# Altium schematic sheet Zotino_BNC_Adapter.SchDoc
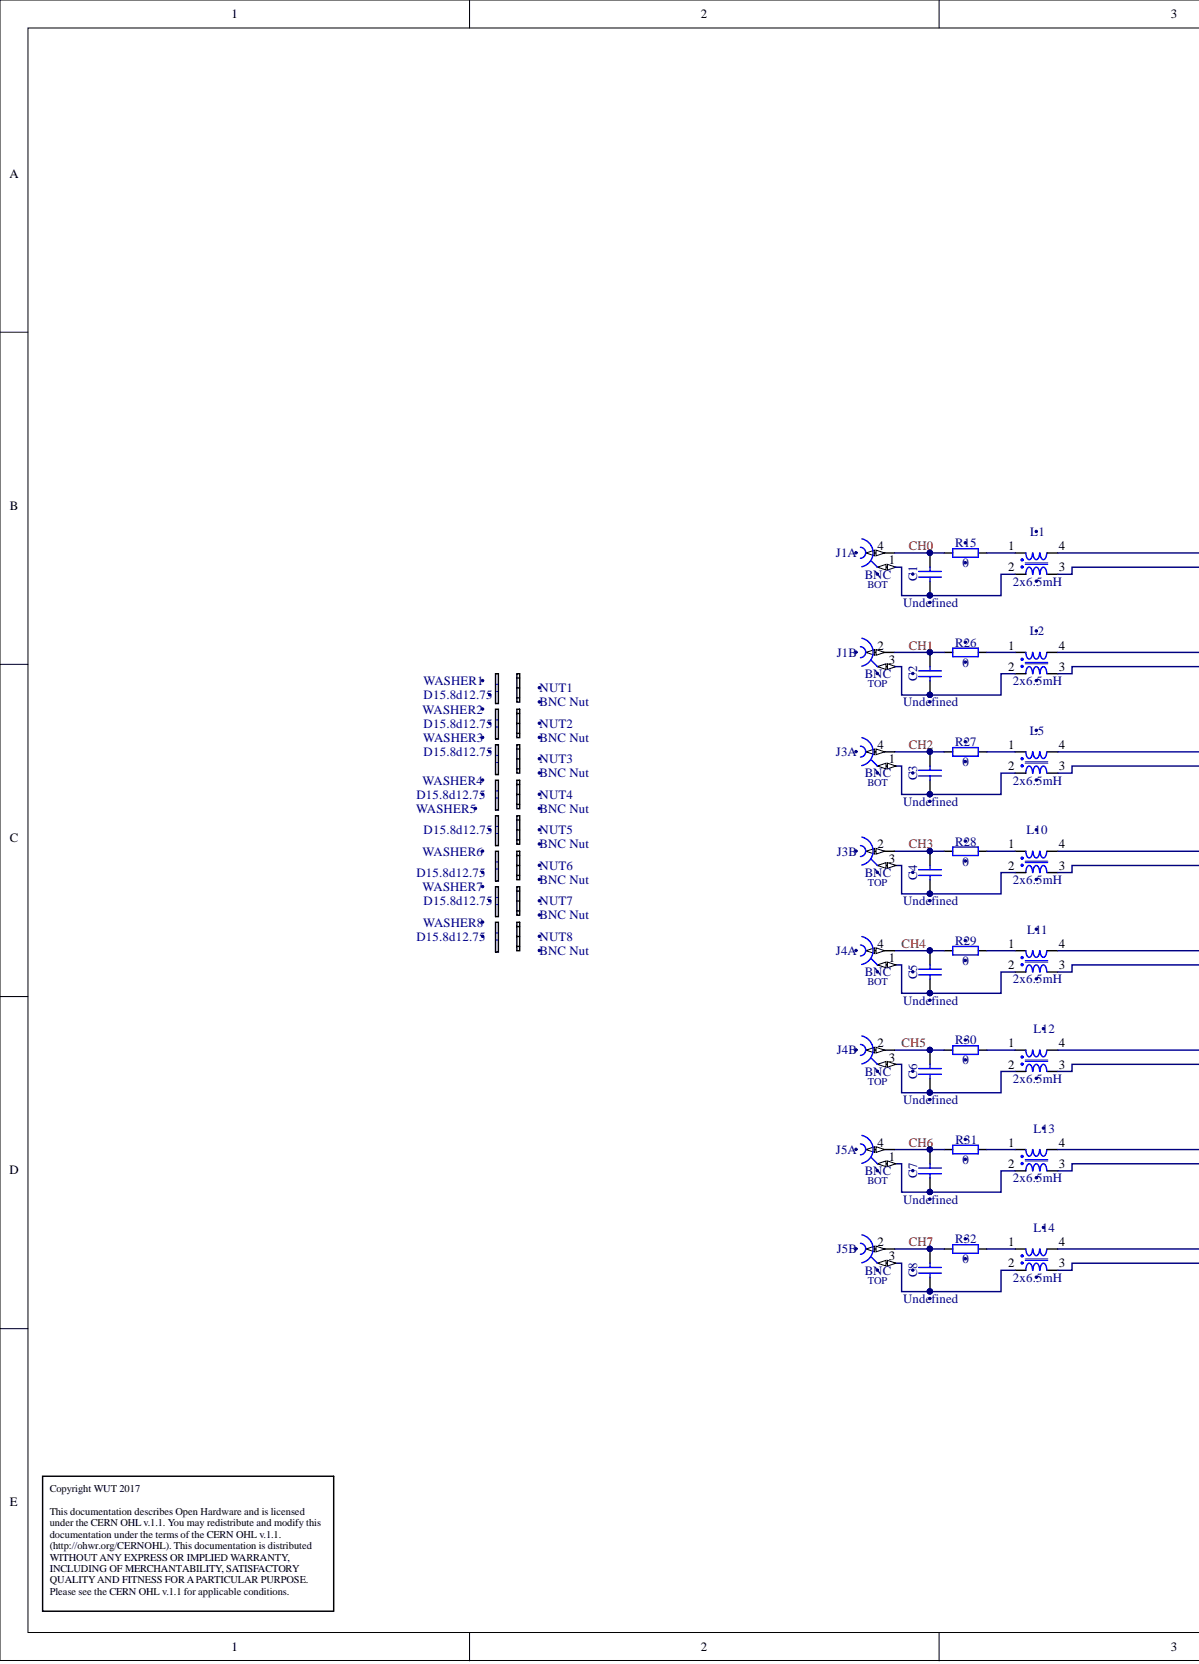
[diagram p0: Zotino_BNC_Adapter.SchDoc, left]
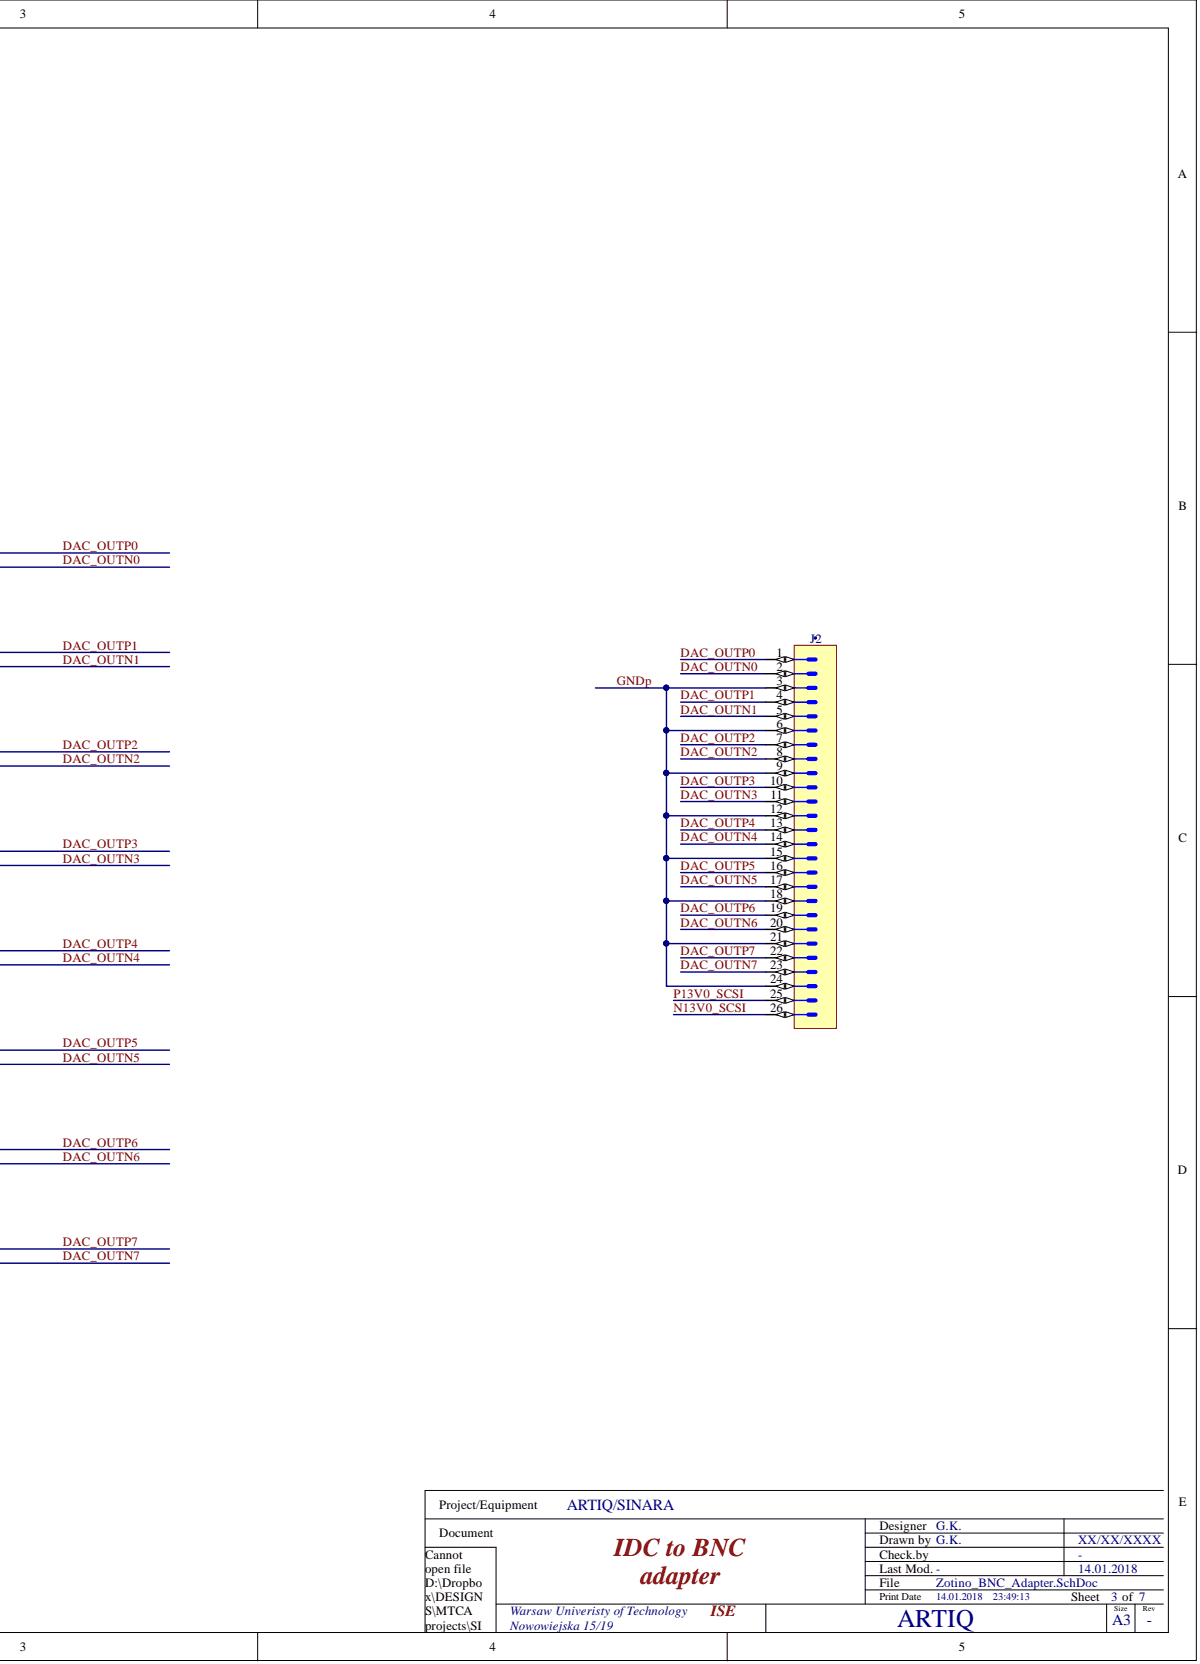
[diagram p1: Zotino_BNC_Adapter.SchDoc, right]

## Parts
C1  Undefined  [CAPC2012X135N]
C2  Undefined  [CAPC2012X135N]
C3  Undefined  [CAPC2012X135N]
C4  Undefined  [CAPC2012X135N]
C5  Undefined  [CAPC2012X135N]
C6  Undefined  [CAPC2012X135N]
C7  Undefined  [CAPC2012X135N]
C8  Undefined  [CAPC2012X135N]
J1  BNC  [RENHOTEC_RHT-610-0049]  (parts A,B)
J2  Header 26  [3M_N2526-6003RB]  (value not drawn)
J3  BNC  [RENHOTEC_RHT-610-0049]  (parts A,B)
J4  BNC  [RENHOTEC_RHT-610-0049]  (parts A,B)
J5  BNC  [RENHOTEC_RHT-610-0049]  (parts A,B)
L1  2x6.5mH  [IND_WURTH_WE-SL2]
L2  2x6.5mH  [IND_WURTH_WE-SL2]
L5  2x6.5mH  [IND_WURTH_WE-SL2]
L10  2x6.5mH  [IND_WURTH_WE-SL2]
L11  2x6.5mH  [IND_WURTH_WE-SL2]
L12  2x6.5mH  [IND_WURTH_WE-SL2]
L13  2x6.5mH  [IND_WURTH_WE-SL2]
L14  2x6.5mH  [IND_WURTH_WE-SL2]
NUT1  BNC Nut  [TE_1-329631-2]
NUT2  BNC Nut  [TE_1-329631-2]
NUT3  BNC Nut  [TE_1-329631-2]
NUT4  BNC Nut  [TE_1-329631-2]
NUT5  BNC Nut  [TE_1-329631-2]
NUT6  BNC Nut  [TE_1-329631-2]
NUT7  BNC Nut  [TE_1-329631-2]
NUT8  BNC Nut  [TE_1-329631-2]
R15  0  [RESC1608X55N]
R26  0  [RESC1608X55N]
R27  0  [RESC1608X55N]
R28  0  [RESC1608X55N]
R29  0  [RESC1608X55N]
R30  0  [RESC1608X55N]
R31  0  [RESC1608X55N]
R32  0  [RESC1608X55N]
WASHER1  D15.8d12.75  [TE_1-1634817-0]
WASHER2  D15.8d12.75  [TE_1-1634817-0]
WASHER3  D15.8d12.75  [TE_1-1634817-0]
WASHER4  D15.8d12.75  [TE_1-1634817-0]
WASHER5  D15.8d12.75  [TE_1-1634817-0]
WASHER6  D15.8d12.75  [TE_1-1634817-0]
WASHER7  D15.8d12.75  [TE_1-1634817-0]
WASHER8  D15.8d12.75  [TE_1-1634817-0]

## Nets
CH0: C1.2 J1.4 R15.1
CH1: C2.2 J1.2 R26.1
CH2: C3.2 J3.4 R27.1
CH3: C4.2 J3.2 R28.1
CH4: C5.2 J4.4 R29.1
CH5: C6.2 J4.2 R30.1
CH6: C7.2 J5.4 R31.1
CH7: C8.2 J5.2 R32.1
DAC_OUTN0: J2.2 L1.3
DAC_OUTN1: J2.5 L2.3
DAC_OUTN2: J2.8 L5.3
DAC_OUTN3: J2.11 L10.3
DAC_OUTN4: J2.14 L11.3
DAC_OUTN5: J2.17 L12.3
DAC_OUTN6: J2.20 L13.3
DAC_OUTN7: J2.23 L14.3
DAC_OUTP0: J2.1 L1.4
DAC_OUTP1: J2.4 L2.4
DAC_OUTP2: J2.7 L5.4
DAC_OUTP3: J2.10 L10.4
DAC_OUTP4: J2.13 L11.4
DAC_OUTP5: J2.16 L12.4
DAC_OUTP6: J2.19 L13.4
DAC_OUTP7: J2.22 L14.4
GNDp: J2.3 J2.6 J2.9 J2.12 J2.15 J2.18 J2.21 J2.24
N13V0_SCSI: J2.26
P13V0_SCSI: J2.25
NetC1_1: C1.1 J1.1 L1.2
NetC2_1: C2.1 J1.3 L2.2
NetC3_1: C3.1 J3.1 L5.2
NetC4_1: C4.1 J3.3 L10.2
NetC5_1: C5.1 J4.1 L11.2
NetC6_1: C6.1 J4.3 L12.2
NetC7_1: C7.1 J5.1 L13.2
NetC8_1: C8.1 J5.3 L14.2
NetL1_1: L1.1 R15.2
NetL2_1: L2.1 R26.2
NetL5_1: L5.1 R27.2
NetL10_1: L10.1 R28.2
NetL11_1: L11.1 R29.2
NetL12_1: L12.1 R30.2
NetL13_1: L13.1 R31.2
NetL14_1: L14.1 R32.2

## Library data of the parts
C1-C8 = CC0805_NO-VALUE: Non-polarised capacitor; Part Description: Reserved Footprint For 0805(2012) Chip Ceramic Capacitor; Database Table Name: Capacitors SMD; Mounted: No; Case: 0805; Component Kind: Standard; Component Type: Standard; CreateDate: 10.12.2008; HelpURL: Undefined; LatestRevisionDate: 11.09.2013; Library Name: Capacitors.DbLib; Manufacturer: Undefined; Manufacturer1 ComponentHeight: 1.35mm; Manufacturer Part Number: CC0805_NO-VALUE; Part Number: CC0805_NO-VALUE; Pin Count: 2; Sense: No; SMD: Yes; Socket: No; Status: None; Value: Undefined; Author: CERN DEM JLC
J1 J3-J5 = (no symbol name): Dual Right Angle 50 Ohm BNC Jack, White Valox Case; Manufacturer: RENHOTEC; Manufacturer Part Number: RHT-610-0049; PressFit: No; ComponentHeight: 29.3mm; Component Kind: Standard; Component Type: Standard; CreateDate: 09.04.2013; Family: BNC; HelpURL: \\cern.ch\dfs\Applications\Altium\Datasheets\BOMAR_364A2595.pdf; LatestRevisionDate: 09.04.2013; Library Name: LIBRARY_SCH.SCHLIB; Mounted: Yes; Part Description: Dual Right Angle 50 Ohm BNC Jack, White Valox Case; Part Number: RENHOTEC_RHT-610-0049; Pin Count: 6; Sense: No; SMD: No; Socket: No; Status: None; Author: GK
J2 = 3M_N2526-6003RB: Connector 26 Male; Part Description: 26 Contacts - Straight .100'' x .100'' Low Profile Four-Wall Header, 2500 Series; Database Table Name: 3M; PressFit: No; ComponentHeight: 9.91mm; Component Kind: Standard; Component Type: Standard; CreateDate: 22.01.2014; Family: Header 26; HelpURL: \\cern.ch\dfs\Applications\Altium\Datasheets\3M_N2526-6003RB.pdf; LatestRevisionDate: 22.01.2014; Library Name: Connectors.DbLib; Manufacturer: 3M; Manufacturer Part Number: N2526-6003RB; Mounted: Yes; Part Number: 3M_N2526-6003RB; Pin Count: 26; Sense: No; SMD: No; Socket: No; Status: None; Author: CERN DEM JLC
L1 L2 L5 L10-L14 = IND_6.5MH_50%_WURTH_WE-SL2-744229: Inductor Common Mode; Manufacturer: WURTH ELEKTRONIK; Manufacturer Part Number: 744229; Part Description: Common Mode SMD Line Filter (WE-SL2 Serie); Database Table Name: Inductors SMD; Power: 400mA; Resistance: 0.95R; Tolerance: ±50%; ComponentHeight: 5.3mm; Component Kind: Standard; Component Type: Standard; CreateDate: 01.10.2012; HelpURL: \\cern.ch\dfs\Applications\Altium\Datasheets\IND_WURTH_WE-SL2_744229.pdf; LatestRevisionDate: 15.10.2012; Library Name: Inductors & Transformers.DbLib; Mounted: Yes; Part Number: IND_6.5MH_50%_WURTH_WE-SL2-744229; Pin Count: 4; Sense: No; SMD: Yes; Socket: No; Status: None; Value: 2x6.5mH; Author: CERN DEM JLC
NUT1-NUT8 = (no symbol name): Manufacturer: TE; Manufacturer Part Number: 1-329631-2; Part Description: BNC NUT 1/2-28UNEF-2B; PressFit: No; ComponentHeight: 3.2mm; Component Kind: Standard; Component Type: Mechanical; CreateDate: 17.04.2014; Description: BNC nut; HelpURL: \\cern.ch\dfs\Applications\Altium\Datasheets\BOSSARD_BN81-1400428.pdf; LatestRevisionDate: 17.04.2014; Library Name: LIBRARY_SCH.SCHLIB; Mounted: Yes; PackageDescription: BNC NUT 1/2-28UNEF-2B; Part Number: TE_1-329631-2; Pin Count: 0; Sense: No; SMD: No; Socket: No; Status: None; Value: BNC Nut; Author: CERN DEM JLC
R15 R26-R32 = R0603_0R_JUMPER: Resistor; Manufacturer: GENERIC; Manufacturer1 Part Number: NRC06Z0; Part Description: 1A (0.05R Max DC Resistance) Zero Ohm Jumper; Database Table Name: Resistors SMD; Case: 0603; Component Kind: Standard; Component Type: Standard; CreateDate: 03.12.2007; HelpURL: \\cern.ch\dfs\Applications\Altium\Datasheets\R0603_NIC_NRC.pdf; LatestRevisionDate: 13.03.2008; Library Name: Resistors.DbLib; Manufacturer1 ComponentHeight: 0.55mm; Manufacturer1 Example: NIC COMPONENT; Manufacturer Part Number: R0603_0R_JUMPER; Mounted: Yes; Part Number: R0603_0R_JUMPER; Pin Count: 2; Sense: No; SMD: Yes; Socket: No; Status: Preferred; Value: 0; Author: CERN DEM JLC
WASHER1-WASHER8 = (no symbol name): BNC Washer; Manufacturer: TE; Manufacturer Part Number: 1-1634817-0; PressFit: No; ComponentHeight: 0.5mm; Component Kind: Standard; Component Type: Mechanical; CreateDate: 27.04.2017; HelpURL: \\cern.ch\dfs\Applications\Altium\Datasheets\BOSSARD_BN83728-8243972.pdf; LatestRevisionDate: 27.04.2017; Library Name: LIBRARY_SCH.SCHLIB; Mounted: Yes; PackageDescription: BNC washer; Part Description: BNC washer; Part Number: TE_1-1634817-0; Pin Count: 0; Sense: No; SMD: No; Socket: No; Status: None; Value: D15.8d12.75; Author: GK
